# Altium schematic sheet 3_ESP8266_Core.SchDoc
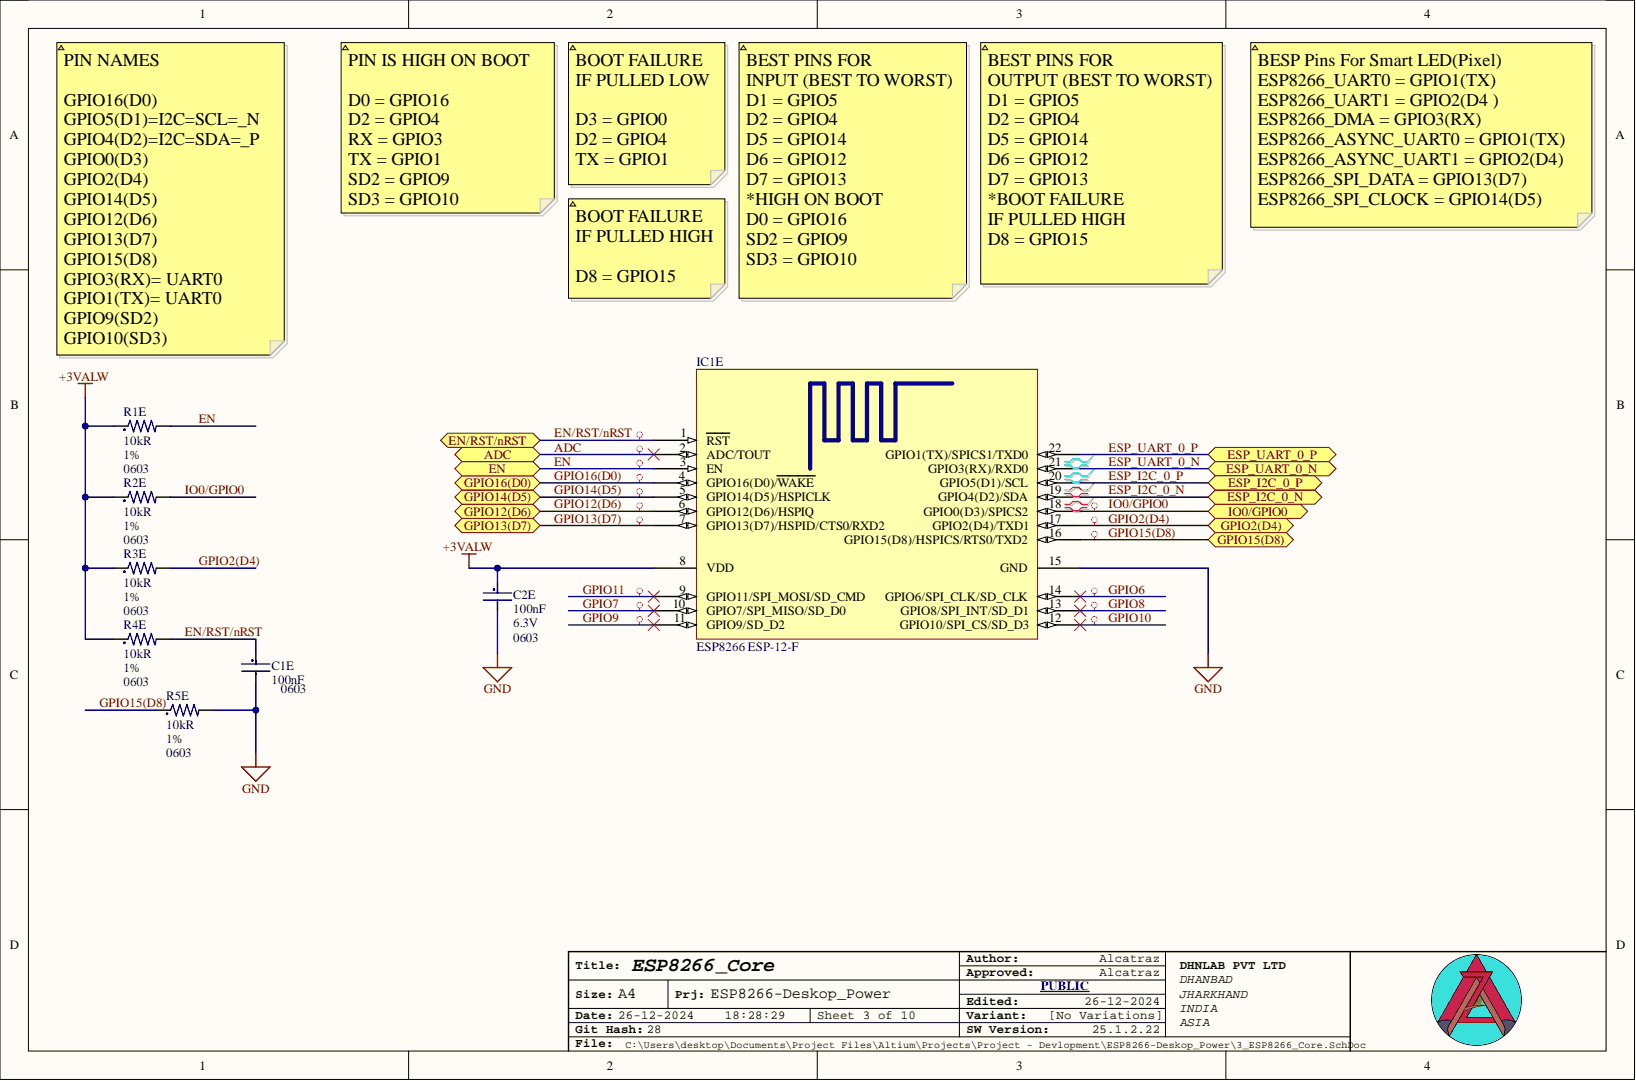
[diagram p0: 3_ESP8266_Core.SchDoc]

## Parts
C1E  value 100nF  Package: 0603  [C0603-IPC_C_No_Silk]  (hidden comment: KGM15AR70J104KM)
C2E  value 100nF  Package: 0603  Voltage: 6.3V  [C0603-IPC_C_No_Silk]  (hidden comment: KGM15AR70J104KM)
IC1E  ESP8266 ESP-12-F  [ESP8266 ESP-12-Large]
R1E  value 10kR  Resistance Tolerance: 1%  [R0603-MFG]  (hidden comment: RC0603FR-0710KL)
R2E  value 10kR  Case Code (Imperial): 0603  Resistance Tolerance: 1%  [R0603-MFG]  (hidden comment: RC0603FR-0710KL)
R3E  value 10kR  Case Code (Imperial): 0603  Resistance Tolerance: 1%  [R0603-MFG]  (hidden comment: RC0603FR-0710KL)
R4E  value 10kR  Case Code (Imperial): 0603  Resistance Tolerance: 1%  [R0603-MFG]  (hidden comment: RC0603FR-0710KL)
R5E  value 10kR  Case Code (Imperial): 0603  Resistance Tolerance: 1%  [R0603-MFG]  (hidden comment: RC0603FR-0710KL)

## Ports
ADC, EN, EN/RST/nRST, ESP_I2C_0_N, ESP_I2C_0_P, ESP_UART_0_N, ESP_UART_0_P, GPIO2(D4), GPIO12(D6), GPIO13(D7), GPIO14(D5), GPIO15(D8), GPIO16(D0), IO0/GPIO0

## Nets
+3VALW: C2E.1 IC1E.8(VDD) R1E.1 R2E.1 R3E.1 R4E.1
GND: C1E.2 C2E.2 IC1E.15(GND) R5E.2
ADC: IC1E.2(ADC/TOUT) ; port ADC
EN: IC1E.3(EN) R1E.2 ; port EN
EN/RST/nRST: C1E.1 IC1E.1(RST) R4E.2 ; port EN/RST/nRST
ESP_I2C_0_N: IC1E.19(GPIO4(D2)/SDA) ; port ESP_I2C_0_N
ESP_I2C_0_P: IC1E.20(GPIO5(D1)/SCL) ; port ESP_I2C_0_P
ESP_UART_0_N: IC1E.21(GPIO3(RX)/RXD0) ; port ESP_UART_0_N
ESP_UART_0_P: IC1E.22(GPIO1(TX)/SPICS1/TXD0) ; port ESP_UART_0_P
GPIO2(D4): IC1E.17(GPIO2(D4)/TXD1) R3E.2 ; port GPIO2(D4)
GPIO6: IC1E.14(GPIO6/SPI_CLK/SD_CLK)
GPIO7: IC1E.10(GPIO7/SPI_MISO/SD_D0)
GPIO8: IC1E.13(GPIO8/SPI_INT/SD_D1)
GPIO9: IC1E.11(GPIO9/SD_D2)
GPIO10: IC1E.12(GPIO10/SPI_CS/SD_D3)
GPIO11: IC1E.9(GPIO11/SPI_MOSI/SD_CMD)
GPIO12(D6): IC1E.6(GPIO12(D6)/HSPIQ) ; port GPIO12(D6)
GPIO13(D7): IC1E.7(GPIO13(D7)/HSPID/CTS0/RXD2) ; port GPIO13(D7)
GPIO14(D5): IC1E.5(GPIO14(D5)/HSPICLK) ; port GPIO14(D5)
GPIO15(D8): IC1E.16(GPIO15(D8)/HSPICS/RTS0/TXD2) R5E.1 ; port GPIO15(D8)
GPIO16(D0): IC1E.4(GPIO16(D0)/WAKE) ; port GPIO16(D0)
IO0/GPIO0: IC1E.18(GPIO0(D3)/SPICS2) R2E.2 ; port IO0/GPIO0

## Library data of the parts
C1E C2E = 100nF_6.3V: Cap Cer 0.1UF 6.3V X7R 0603; Manufacturer: KYOCERA AVX; Tol: 10%; Category: Ceramic Capacitors; Component Kind: Standard; Library Name: Lib_Capacitor_0603.IntLib; Manufacturer Part Number: KGM15AR70J104KM; Pricing 1: 1=0.1, 10=0.032, 50=0.0186, 100=0.0144, 500=0.01082, 1000=0.0085, 2500=0.00772, 5000=0.00711 (USD); Stock 1: 117167; Supplier 1: Digikey; Supplier Part Number 1: 478-KGM15AR70J104KMCT-ND
  C1E: Voltage: 6.3V
R1E R2E R3E R4E R5E = 10K: SMD Chip Resistor, 10 kOhm, ± 1%, 100 mW, 0603 [1608 Metric], Thick Film, General Purpose; Manufacturer: Yageo; Max Power Dissipation: 100mW; Temperature Coefficient: 100ppm/°C; Voltage Rating: 75V; Voltage Rating (DC): 50V; Case Code (Metric): 1608; Case/Package: 0603; Category: Chip SMD Resistors; Component Kind: Standard; Construction: Rectangular; Depth: 0.8mm; Features: Moisture Resistant; Height: 0.45mm; Lead Free: 5697LeadFree; Lead Pitch: 0.3mm; Length: 1.6mm; Library Name: Lib_Register_0603.IntLib; Manufacturer Part Number: RC0603FR-0710KL; Max Operating Temperature: 155°C; Military Standard: Not; Min Operating Temperature: -55°C; Number of Terminations: 2; Packaging: Tape and Reel; Pins: 2; Power Rating: 100mW; Pricing 1: 5000=0.0015, 50000=0.0014, 150000=0.0014, 375000=0.0013, 1000000=0.0012 (USD); Reach SVHC Compliant: No; Resistor Type: Thick Film; RoHS: Yes; RoHS Compliant: Yes; Series: RC; Stock 1: 44660000; Supplier 1: Future Electronics; Supplier Part Number 1: 4023214; Termination: SMD/SMT; Tolerance: 1%; Weight: 7.1E-05oz; Width: 0.8mm
  R1E: Case Code (Imperial): 0603
IC1E = ESP8266 ESP-12-F: Component Kind: Standard; Description: ESP8266 ESP-12-F; Library Name: Lib_ESP8266.IntLib
